# Altium schematic sheet EsquematicoPlacaV5.SchDoc
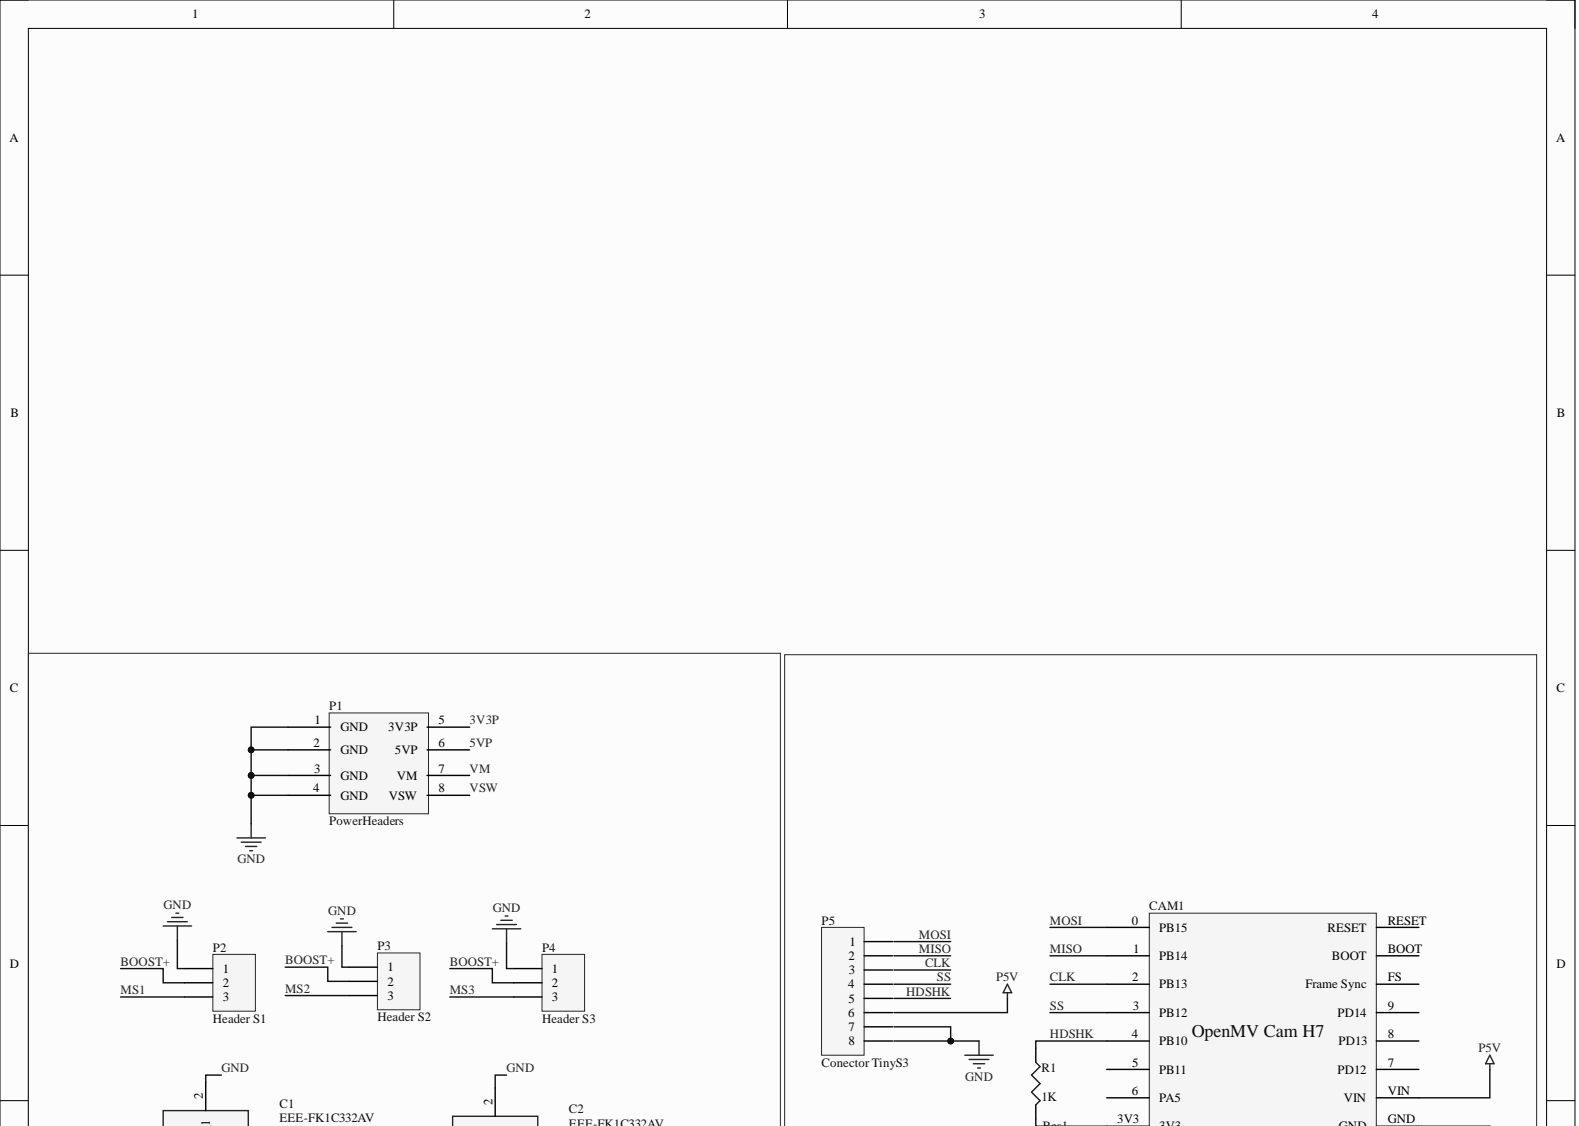
[diagram p0: EsquematicoPlacaV5.SchDoc, top]
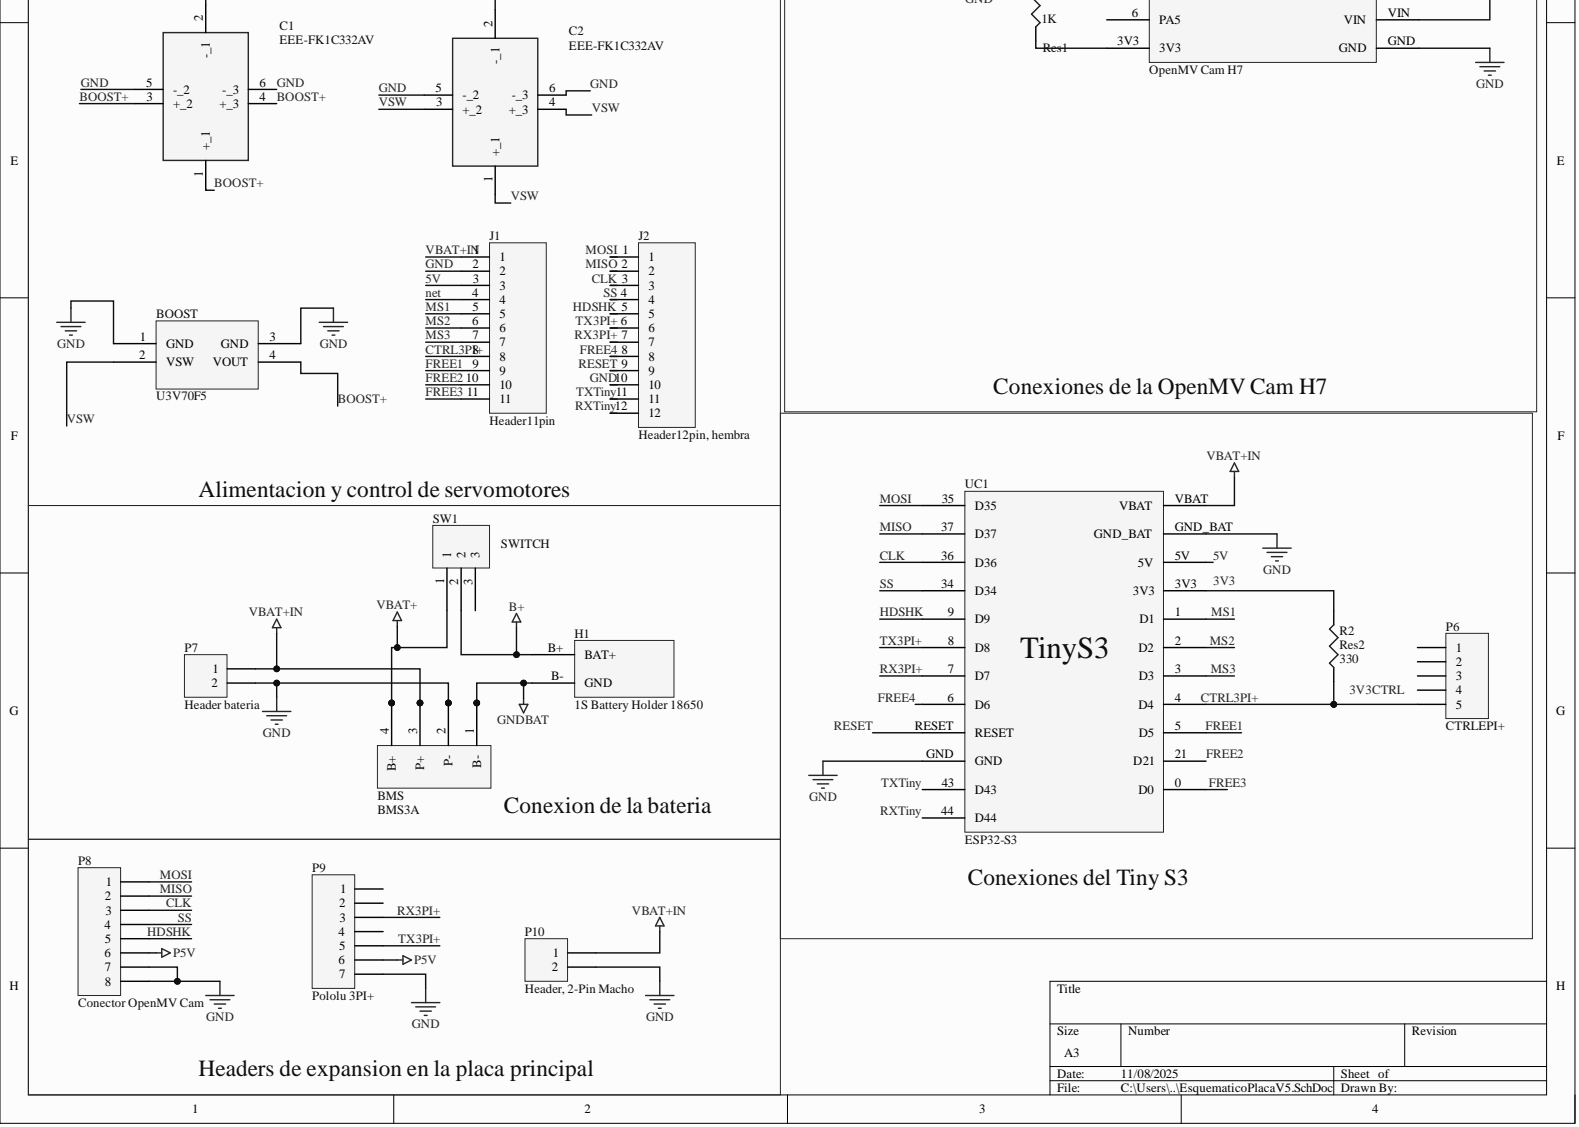
[diagram p1: EsquematicoPlacaV5.SchDoc, bottom]

## Parts
BMS  BMS3A  [PCBComponent_1]
BOOST  U3V70F5  [U3V70F5]
C1  EEE-FK1C332AV  [EEEFK0J682AV]
C2  EEE-FK1C332AV  [EEEFK0J682AV]
CAM1  OpenMV Cam H7  [OpenMVCamH7]
H1  1S Battery Holder 18650  [18650BatteryHolder]
J1  Header11pin  [HEADER11PIN]
J2  Header12pin, hembra  [Header12pin]
P1  PowerHeaders  [PowerHeaders]
P2  Header S1  [HDR1X3]
P3  Header S2  [HDR1X3]
P4  Header S3  [HDR1X3]
P5  Conector TinyS3  [HDR1X8]
P6  CTRLEPI+  [HDR1X5]
P7  Header bateria  [HDR1X2]
P8  Conector OpenMV Cam  [HDR1X8]
P9  Pololu 3PI+  [HDR1X7]
P10  Header, 2-Pin Macho  [HDR1X2]
R1  Res1  value 1K  [14-1210]
R2  Res2  value 330  [14-1210]
SW1  SWITCH  [SW-313]
UC1  ESP32-S3  [TinyS3]

## Nets
B+: H1.B+ SW1.2
GNDBAT: BMS.1(B-) H1.B-
P5V: CAM1.VIN P8.6 P9.6
VBAT+: BMS.4(B+) SW1.1
3V3P: P1.5(3V3P)
5V: J1.3 UC1.5V
5VP: P1.6(5VP)
BOOST+: BOOST.4(VOUT) C1.1(+_1) C1.3(+_2) C1.4(+_3) P2.2 P3.2 P4.2
CLK: CAM1.2(PB13) J2.3 P8.3 UC1.36(D36)
CTRL3PI+: J1.8 P6.5 R2.1 UC1.4(D4)
FREE1: J1.9 UC1.5(D5)
FREE2: J1.10 UC1.21(D21)
FREE3: J1.11 UC1.0(D0)
FREE4: J2.8 UC1.6(D6)
GND: BMS.2(P-) BOOST.1(GND) BOOST.3(GND) C1.2(-_1) C1.5(-_2) C1.6(-_3) C2.2(-_1) C2.5(-_2) C2.6(-_3) CAM1.GND J1.2 J2.10 P1.1(GND) P1.2(GND) P1.3(GND) P1.4(GND) P2.1 P3.1 P4.1 P7.2 P8.7 P8.8 P9.7 P10.2 UC1.GND UC1.GND_BAT
HDSHK: CAM1.4(PB10) J2.5 P8.5 R1.1 UC1.9(D9)
MISO: CAM1.1(PB14) J2.2 P8.2 UC1.37(D37)
MOSI: CAM1.0(PB15) J2.1 P8.1 UC1.35(D35)
MS1: J1.5 P2.3 UC1.1(D1)
MS2: J1.6 P3.3 UC1.2(D2)
MS3: J1.7 P4.3 UC1.3(D3)
net: J1.4
RESET: J2.9 UC1.RESET
RX3PI+: J2.7 P9.3 UC1.7(D7)
RXTiny: J2.12 UC1.44(D44)
SS: CAM1.3(PB12) J2.4 P8.4 UC1.34(D34)
TX3PI+: J2.6 P9.5 UC1.8(D8)
TXTiny: J2.11 UC1.43(D43)
VBAT+IN: BMS.3(P+) J1.1 P7.1 P10.1 UC1.VBAT
VM: P1.7(VM)
VSW: BOOST.2(VSW) C2.1(+_1) C2.3(+_2) C2.4(+_3) P1.8(VSW)
NetCAM1_3V3: CAM1.3V3 R1.2
NetR2_2: R2.2 UC1.3V3
3V3:
3V3CTRL:

Not connected: CAM1 5(PB11) 6(PA5) 7(PD12) 8(PD13) 9(PD14) BOOT FS(Frame_Sync) RESET; P5 1 2 3 4 5 6 7 8; P6 1 2 3 4; P9 1 2 4; SW1 3

## Library data of the parts
C1 C2 = EEE-FK1C332AV: Capacitor Polarised; Manufacturer_Name: Panasonic; Component Kind: Standard; Datasheet Link: http://industrial.panasonic.com/cdbs/www-data/pdf/RDE0000/ABA0000C1178.pdf; Height: 16.8mm; Manufacturer_Part_Number: EEE-FK1C332AV; Mouser Part Number: 667-EEE-FK1C332AV; Mouser Price/Stock: https://www.mouser.co.uk/ProductDetail/Panasonic/EEE-FK1C332AV?qs=mt7EBqA2jzg8hRixDGx%252BUQ%3D%3D
J2 = Header12pin: Component Kind: Standard; Library Name: Headers.IntLib
P2-P4 = Header 3: Header, 3-Pin; Component Kind: Standard; LatestRevisionDate: 17-Jul-2002; LatestRevisionNote: Re-released for DXP Platform.; Library Name: Miscellaneous Connectors.IntLib; Signal Integrity: Connector; Publisher: Altium Limited
P5 = Header 8: Header, 8-Pin, Conector TinyS3; Component Kind: Standard; LatestRevisionDate: 17-Jul-2002; LatestRevisionNote: Re-released for DXP Platform.; Library Name: Miscellaneous Connectors.IntLib; Signal Integrity: Connector; Publisher: Altium Limited
P6 = Header 5: Header, 5-Pin; Component Kind: Standard; LatestRevisionDate: 17-Jul-2002; LatestRevisionNote: Re-released for DXP Platform.; Library Name: Miscellaneous Connectors.IntLib; Signal Integrity: Connector; Publisher: Altium Limited
P7 = Header 2: Header, 2-Pin; Component Kind: Standard; LatestRevisionDate: 17-Jul-2002; LatestRevisionNote: Re-released for DXP Platform.; Library Name: Miscellaneous Connectors.IntLib; Signal Integrity: Connector; Publisher: Altium Limited
P8 = Header 8: Header, 8-Pin; Component Kind: Standard; LatestRevisionDate: 17-Jul-2002; LatestRevisionNote: Re-released for DXP Platform.; Library Name: Miscellaneous Connectors.IntLib; Signal Integrity: Connector; Publisher: Altium Limited
P9 = Header 7: Header, 7-Pin; Component Kind: Standard; LatestRevisionDate: 17-Jul-2002; LatestRevisionNote: Re-released for DXP Platform.; Library Name: Miscellaneous Connectors.IntLib; Signal Integrity: Connector; Publisher: Altium Limited
P10 = Header 2: Header, 2-Pin, Conector BAT Pololu; Component Kind: Standard; LatestRevisionDate: 17-Jul-2002; LatestRevisionNote: Re-released for DXP Platform.; Library Name: Miscellaneous Connectors.IntLib; Signal Integrity: Connector; Publisher: Altium Limited
R1 R2 = Res3: Resistor; Component Kind: Standard; LatestRevisionDate: 29-May-2009; LatestRevisionNote: IPC-7351 Footprint Added.; Library Name: Miscellaneous Devices.IntLib; PackageDescription: Chip Resistor; PackageReference: J1-0603; Published: 8-Jun-2000; Signal Integrity: Res; Simulation: RESISTOR; Publisher: Altium Limited
SW1 = SW-313: Matched GaAs SPDT Switch,  DC-3.0 GHz with TTL/CMOS Control Input Rev. V6  SW-313-PIN, 3A, 125V; Component Kind: Standard; Library Name: Headers.IntLib
UC1 = TinyS3: Component Kind: Standard; Library Name: TesisLibrary.IntLib
BMS = BMS3A: Component Kind: Standard; Library Name: TesisLibrary.IntLib
BOOST = U3V70F5: Component Kind: Standard; Library Name: TesisLibrary.IntLib
CAM1 = OpenMV Cam H7: Component Kind: Standard; Library Name: TesisLibrary.IntLib
H1 = 1S Battery Holder 18650: Component Kind: Standard; Library Name: Boards.SchLib
J1 = Header11pin: Component Kind: Standard; Library Name: Headers.IntLib
P1 = PowerHeaders: Component Kind: Standard; Library Name: TesisLibrary.IntLib
